# Altium schematic sheet npm1300_qeaa_config4.SchDoc
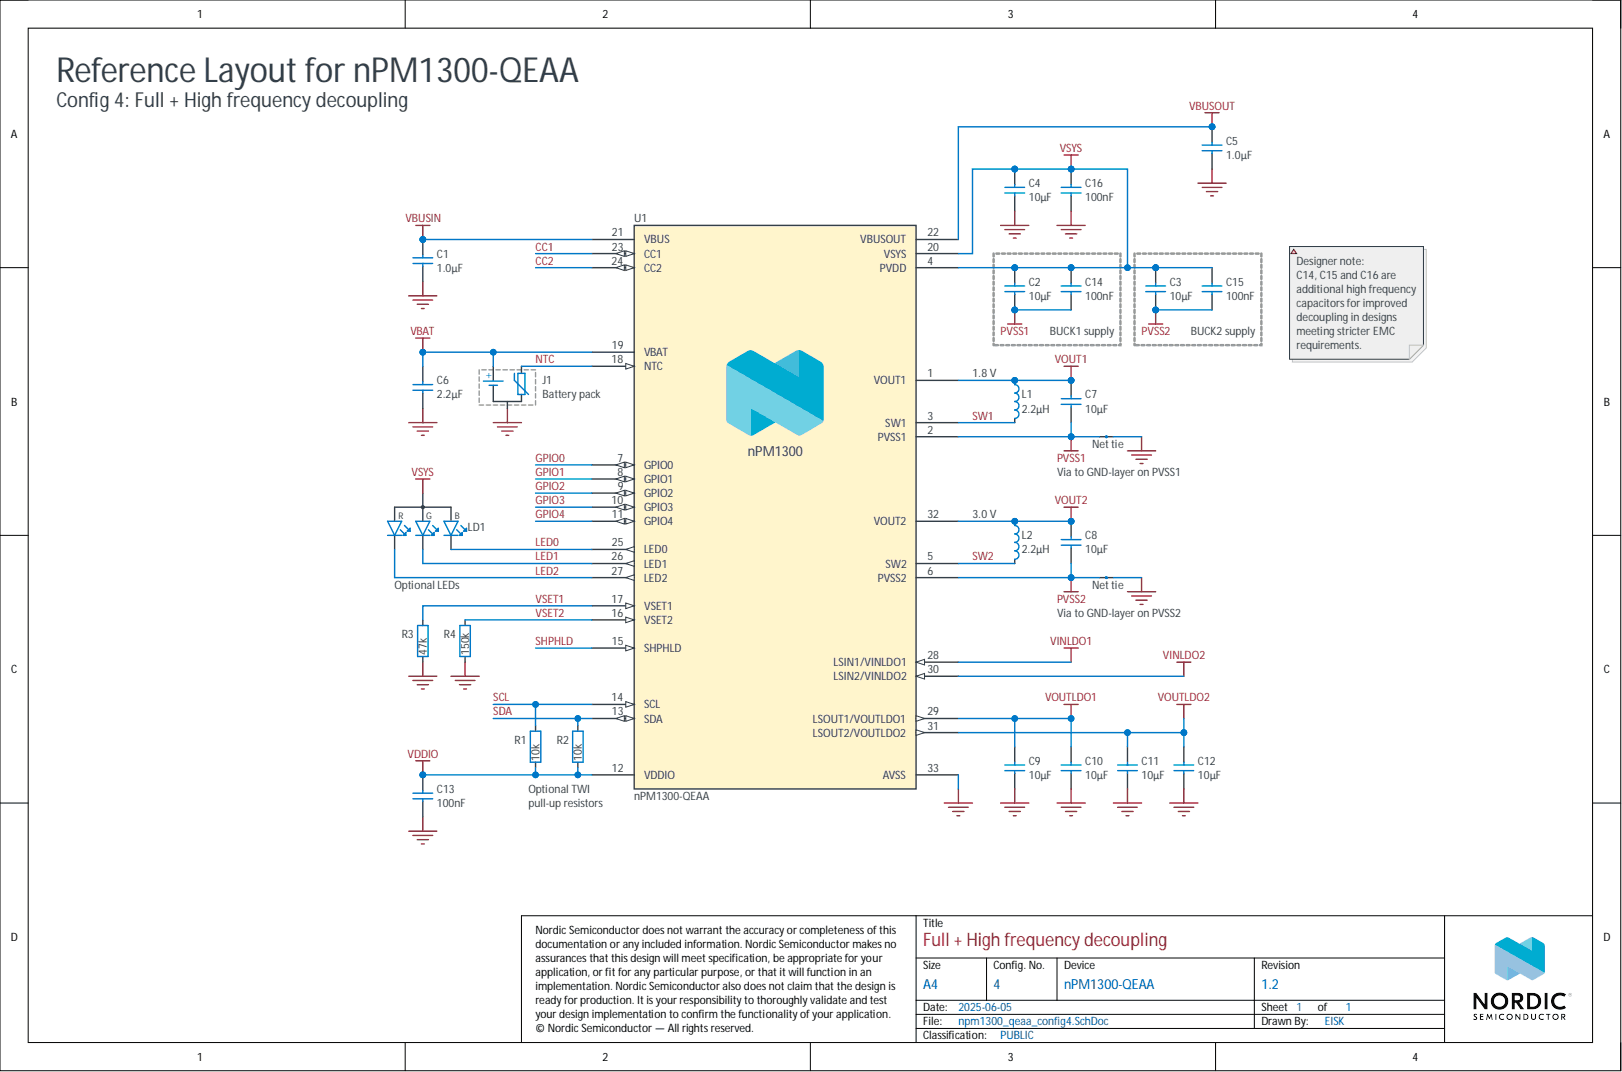
[diagram p0: npm1300_qeaa_config4.SchDoc]

## Parts
C1  1.0µF  [CAPC1608X06L]
C2  10µF  [CAPC1608X06L]
C3  10µF  [CAPC1608X06L]
C4  10µF  [CAPC1608X06L]
C5  1.0µF  [CAPC1608X06L]
C6  2.2µF  [CAPC1608X06L]
C7  10µF  [CAPC1608X06L]
C8  10µF  [CAPC1608X06L]
C9  10µF  [CAPC1608X06L]
C10  10µF  [CAPC1608X06L]
C11  10µF  [CAPC1608X06L]
C12  10µF  [CAPC1608X06L]
C13  100nF  [CAPC0603X03L_C]
C14  100nF  [CAPC1005X04L]
C15  100nF  [CAPC1005X04L]
C16  100nF  [CAPC1005X04L]
L1  2.2µH  [INDC2016X10N]
L2  2.2µH  [INDC2016X10N]
NT1  Net tie  [2 PIN STARPOINT]
NT2  Net tie  [2 PIN STARPOINT]
R1  10k  [RESC0603X03L_C]
R2  10k  [RESC0603X03L_C]
R3  47k  [RESC0603X03L_C]
R4  150k  [RESC0603X03L_C]
U1  nPM1300-QEAA  [Nordic_QFN50P500X500X90-33N]

## Nets
GND: C1.1 C4.1 C5.1 C6.1 C9.1 C10.1 C11.1 C12.1 C13.2 C16.2 NT1.1 NT2.1 R3.2 R4.2 U1.33(AVSS)
PVSS1: C2.1 C7.1 C14.2 NT1.2 U1.2(PVSS1)
PVSS2: C3.1 C8.1 C15.2 NT2.2 U1.6(PVSS2)
VBAT: C6.2 U1.19(VBAT)
VBUSIN: C1.2 U1.21(VBUS)
VBUSOUT: C5.2 U1.22(VBUSOUT)
VDDIO: C13.1 R1.2 R2.2 U1.12(VDDIO)
VINLDO1: U1.28(LSIN1/VINLDO1)
VINLDO2: U1.30(LSIN2/VINLDO2)
VOUT1: C7.2 L1.1 U1.1(VOUT1)
VOUT2: C8.2 L2.1 U1.32(VOUT2)
VOUTLDO1: C9.2 C10.2 U1.29(LSOUT1/VOUTLDO1)
VOUTLDO2: C11.2 C12.2 U1.31(LSOUT2/VOUTLDO2)
VSYS: C2.2 C3.2 C4.2 C14.1 C15.1 C16.1 U1.4(PVDD) U1.20(VSYS)
CC1: U1.23(CC1)
CC2: U1.24(CC2)
GPIO0: U1.7(GPIO0)
GPIO1: U1.8(GPIO1)
GPIO2: U1.9(GPIO2)
GPIO3: U1.10(GPIO3)
GPIO4: U1.11(GPIO4)
LED0: U1.25(LED0)
LED1: U1.26(LED1)
LED2: U1.27(LED2)
NTC: U1.18(NTC)
SCL: R1.1 U1.14(SCL)
SDA: R2.1 U1.13(SDA)
SHPHLD: U1.15(SHPHLD)
SW1: L1.2 U1.3(SW1)
SW2: L2.2 U1.5(SW2)
VSET1: R3.1 U1.17(VSET1)
VSET2: R4.1 U1.16(VSET2)

## Library data of the parts
C1 C5 = C0603-1µ0-10V-X5R: Capacitor, Ceramic, 1.0µF 10V X5R 0603,±10%; Part number NEMS: 100200528; Artikkelnr Noca: N.A.; Component Status: Active; Database Table Name: Parts$; Pad Count: 2; Component Class: Capacitors; Component Kind: Standard; ComponentLink1Description: Datasheet; ComponentLink1URL: N.A.; ComponentLink2Description: Supplier link; ComponentLink2URL: N.A.; Footprint Doc: 0603; HelpURL: N.A.; LatestRevisionDate: 2022-10-06; Library Name: AltiumLib.DbLib; Manufacturer: N.A.; Manufacturer Part Number: N.A.; Note: 10V; Part Number: C0603-1µ0-10V-X5R; Part number IPS: N.A.; Part number Norautron: N.A.; PartId: 100200528; Published: 2022-10-06; Supplier 1: N.A.; Supplier 2: N.A.; Supplier Part Number 1: N.A.; Supplier Part Number 2: N.A.; Value: 1.0µF; Author: getr
C2-C4 C7-C12 = C0603-10µ: Capacitor, X5R, ±20%; Manufacturer: N.A.; Part number IPS: 00000007785; Part number NEMS: 100200275; Artikkelnr Noca: 20039234; Component Status: Active; Database Table Name: Parts$; Note: 6.3V; Pad Count: 2; Component Class: Capacitors; Component Kind: Standard; ComponentLink1Description: Datasheet; ComponentLink1URL: N.A.; ComponentLink2Description: Supplier link; ComponentLink2URL: N.A.; Footprint Doc: 0603; HelpURL: N.A.; LatestRevisionDate: 2011-01-17; Library Name: AltiumLib.DbLib; Manufacturer Part Number: N.A.; Part Number: C0603-10µ; Part number Norautron: N.A.; PartId: 100200275; Published: 2011-01-17; Supplier 1: N.A.; Supplier 2: N.A.; Supplier Part Number 1: N.A.; Supplier Part Number 2: N.A.; Value: 10µF; Author: rsk
C6 = C0603-2.2µ-16V-X7R: Capacitor, Ceramic, X7R, ±10%, 16V; Manufacturer: Taiyo Yuden; Manufacturer Part Number: EMK107BB7225KA-T; Part number NEMS: 100200439; Artikkelnr Noca: N.A.; Component Status: Active; Database Table Name: Parts$; Pad Count: 2; Component Class: Capacitors; Component Kind: Standard; ComponentLink1Description: Datasheet; ComponentLink1URL: https://ds.yuden.co.jp/TYCOMPAS/ut/specSheet?pn=EMK107BB7225KA-T; ComponentLink2Description: Supplier link; ComponentLink2URL: https://ds.yuden.co.jp/TYCOMPAS/ut/detail?pn=EMK107BB7225KA-T&u=M; Footprint Doc: 0603; HelpURL: https://www.digikey.no/product-detail/en/taiyo-yuden/EMK107BB7225KA-T/587-5835-2-ND/4970646; LatestRevisionDate: 2020-10-13; Library Name: AltiumLib.DbLib; Note: 16V; Part Number: C0603-2.2µ-16V-X7R; Part number IPS: N.A.; Part number Norautron: N.A.; PartId: 100200439; Published: 2020-02-03; Supplier 1: Digi-Key; Supplier 2: N.A.; Supplier Part Number 1: 587-5835-2-ND; Supplier Part Number 2: N.A.; Value: 2.2µF; Author: rsk
C13 = C0201-100n: Capacitor, X5R, ±10%, 100nF, 16V; Part number IPS: 00000001248, 00000002505; Part number NEMS: 100200078; Part number Norautron: 262071862; Artikkelnr Noca: N.A.; Component Status: Active; Database Table Name: Parts$; Pad Count: 2; Component Class: Capacitors; Component Kind: Standard; ComponentLink1Description: Datasheet; ComponentLink1URL: N.A.; ComponentLink2Description: Supplier link; ComponentLink2URL: N.A.; Footprint Doc: 0201; HelpURL: N.A.; LatestRevisionDate: 2024-06-27; Library Name: AltiumLib.DbLib; Manufacturer: N.A.; Manufacturer Part Number: N.A.; Note: 16V; Part Number: C0201-100n; PartId: 100200078; Published: 2014-11-13; Supplier 1: N.A.; Supplier 2: N.A.; Supplier Part Number 1: N.A.; Supplier Part Number 2: N.A.; Value: 100nF; Author: rsk
C14-C16 = C0402-100n-16V-X7R-C0402C104M4RAC7867: Capacitor, Ceramic, X7R, ±20%, 16V; Manufacturer: Kemet; Part number NEMS: 100200504; Artikkelnr Noca: N.A.; Component Status: Active; Database Table Name: Parts$; Pad Count: 2; Component Class: Capacitors; Component Kind: Standard; ComponentLink1Description: Datasheet; ComponentLink1URL: https://api.kemet.com/component-edge/download/datasheet/C0402C104M4RACTU.pdf; ComponentLink2Description: Supplier link; ComponentLink2URL: https://www.digikey.no/no/products/detail/kemet/C0402C104M4RAC7867/2196240?s=N4IgTCBcDaIMIAYAsCxwIzILJIEoEE4B2ADgDYiQBdAXyA; Footprint Doc: 0402; HelpURL: https://www.kemet.com/en/us/capacitors/ceramic/kc-link/product/C0402C104M4RACTU.html; LatestRevisionDate: 2024-03-23; Library Name: AltiumLib.DbLib; Manufacturer Part Number: C0402C104M4RAC7867; Note: 16V; Part Number: C0402-100n-16V-X7R-C0402C104M4RAC7867; Part number IPS: N.A.; Part number Norautron: N.A.; PartId: 100200504; Published: 2022-03-23; Supplier 1: Digi-Key; Supplier 2: N.A.; Supplier Part Number 1: 399-C0402C104M4RAC7867CT-ND; Supplier Part Number 2: N.A.; Value: 100nF; Author: getr
L1 L2 = L0806-2µ2-2500mA-73mOhm-CIGT201610EH2R2MNE: Inductor, Irms=2.5A, Isat=2.9A, ±20%, DCR=73mΩ; Manufacturer: Samsung Electro-Mechanics America; Part number NEMS: 100300400; Part number Norautron: 26521789; Artikkelnr Noca: N.A.; Component Status: Active; Database Table Name: Parts$; Note: Unshielded Thin Film Inductor; Pad Count: 2; Component Class: Inductors; Component Kind: Standard; ComponentLink1Description: Datasheet; ComponentLink1URL: https://media.digikey.com/pdf/Data%20Sheets/Samsung%20PDFs/CIGT201610EH2R2MNE_Spec.pdf; ComponentLink2Description: Supplier link; ComponentLink2URL: https://www.digikey.com/en/products/detail/samsung-electro-mechanics/CIGT201610EH2R2MNE/7041223?s=N4IgTCBcDaIMIEkDiAVMAGAjANk%2BgogBJgBKYAsgHL4gC6AvkA; Footprint Doc: 0806; HelpURL: http://product.samsungsem.com/pi/CIGT201610EH2R2MN.do; LatestRevisionDate: 2022-09-07; Library Name: AltiumLib.DbLib; Manufacturer Part Number: CIGT201610EH2R2MNE; Part Number: L0806-2µ2-2500mA-73mOhm-CIGT201610EH2R2MNE; Part number IPS: N.A.; PartId: 100300400; Published: 2021-02-03; Supplier 1: Digi-Key; Supplier 2: Mouser; Supplier Part Number 1: 1276-6901-1-ND; Supplier Part Number 2: 187-CIGT201610EH2R2M; Value: 2.2µH; Author: rsk
NT1 NT2 = 2 pin starpoint: Starpoint; Part number NEMS: 100500603; Artikkelnr Noca: N.A.; Component Status: Active; Database Table Name: Parts$; Pad Count: 2; Component Class: Connectors; Component Kind: Net Tie; ComponentLink1Description: Datasheet; ComponentLink1URL: N.A.; ComponentLink2Description: Supplier link; ComponentLink2URL: N.A.; Footprint Doc: N.A.; HelpURL: N.A.; LatestRevisionDate: 2017-08-31; Library Name: AltiumLib.DbLib; Manufacturer: N.A.; Manufacturer Part Number: N.A.; Note: N.A.; Part Number: 2 pin starpoint; Part number IPS: N.A.; Part number Norautron: N.A.; PartId: 100500603; Published: 2017-08-31; Supplier 1: N.A.; Supplier 2: N.A.; Supplier Part Number 1: N.A.; Supplier Part Number 2: N.A.; Value: Net tie; Author: rsk
R1 R2 = R0201-10k: Resistor, ±1%, 0.05W; Part number IPS: 00000001255; Part number NEMS: 100100065; Part number Norautron: 26107101; Artikkelnr Noca: N.A.; Component Status: Active; Database Table Name: Parts$; Note: Chip Res 1%; Pad Count: 2; Component Class: Resistors; Component Kind: Standard; ComponentLink1Description: Datasheet; ComponentLink1URL: N.A.; ComponentLink2Description: Supplier link; ComponentLink2URL: N.A.; Footprint Doc: 0201; HelpURL: N.A.; LatestRevisionDate: 2014-11-13; Library Name: AltiumLib.DbLib; Manufacturer: N.A.; Manufacturer Part Number: N.A.; Part Number: R0201-10k; PartId: 100100065; Published: 2014-11-13; Supplier 1: N.A.; Supplier 2: N.A.; Supplier Part Number 1: N.A.; Supplier Part Number 2: N.A.; Value: 10k; Author: rsk
R3 = R0201-47k: Resistor, ±1%, 0.05W; Part number IPS: 00000001423; Part number NEMS: 100100076; Artikkelnr Noca: N.A.; Component Status: Active; Database Table Name: Parts$; Note: Chip Res 1%; Pad Count: 2; Component Class: Resistors; Component Kind: Standard; ComponentLink1Description: Datasheet; ComponentLink1URL: N.A.; ComponentLink2Description: Supplier link; ComponentLink2URL: N.A.; Footprint Doc: 0201; HelpURL: N.A.; LatestRevisionDate: 2014-11-13; Library Name: AltiumLib.DbLib; Manufacturer: N.A.; Manufacturer Part Number: N.A.; Part Number: R0201-47k; Part number Norautron: N.A.; PartId: 100100076; Published: 2014-11-13; Supplier 1: N.A.; Supplier 2: N.A.; Supplier Part Number 1: N.A.; Supplier Part Number 2: N.A.; Value: 47k; Author: rsk
R4 = R0201-150k: Resistor, ±1%, 0.05W; Part number IPS: 00000001422; Part number NEMS: 100100082; Artikkelnr Noca: N.A.; Component Status: Active; Database Table Name: Parts$; Note: Chip Res 1%; Pad Count: 2; Component Class: Resistors; Component Kind: Standard; ComponentLink1Description: Datasheet; ComponentLink1URL: N.A.; ComponentLink2Description: Supplier link; ComponentLink2URL: N.A.; Footprint Doc: 0201; HelpURL: N.A.; LatestRevisionDate: 2014-11-13; Library Name: AltiumLib.DbLib; Manufacturer: N.A.; Manufacturer Part Number: N.A.; Part Number: R0201-150k; Part number Norautron: N.A.; PartId: 100100082; Published: 2014-11-13; Supplier 1: N.A.; Supplier 2: N.A.; Supplier Part Number 1: N.A.; Supplier Part Number 2: N.A.; Value: 150k; Author: rsk
U1 = nPM1300-QEAA: Li-Ion/Li-Polymer USB-C battery charger with high efficiency buck regulators, LDOs or load switches, LED drivers, TWI and VBUSOUT; Manufacturer: Nordic Semiconductor; Part number NEMS: 102000291; Artikkelnr Noca: N.A.; Component Status: Active; Database Table Name: Parts$; Pad Count: 33; Component Class: Nordic_Devices; Component Kind: Standard; ComponentLink1Description: Datasheet; ComponentLink1URL: N.A.; ComponentLink2Description: Supplier link; ComponentLink2URL: N.A.; Footprint Doc: QFN-32; HelpURL: N.A.; LatestRevisionDate: 2023-05-25; Library Name: AltiumLib.DbLib; Manufacturer Part Number: nPM1300-QEAA; Note: N.A.; Part Number: nPM1300-QEAA; Part number IPS: N.A.; Part number Norautron: N.A.; PartId: 102000291; Published: 2022-02-17; Supplier 1: Nordic Semiconductor; Supplier 2: N.A.; Supplier Part Number 1: nPM1300-QEAA; Supplier Part Number 2: N.A.; Value: nPM1300-QEAA; Author: eisk
